# Altium schematic sheet BSE_SCH.SchDoc(BSE_SCH)
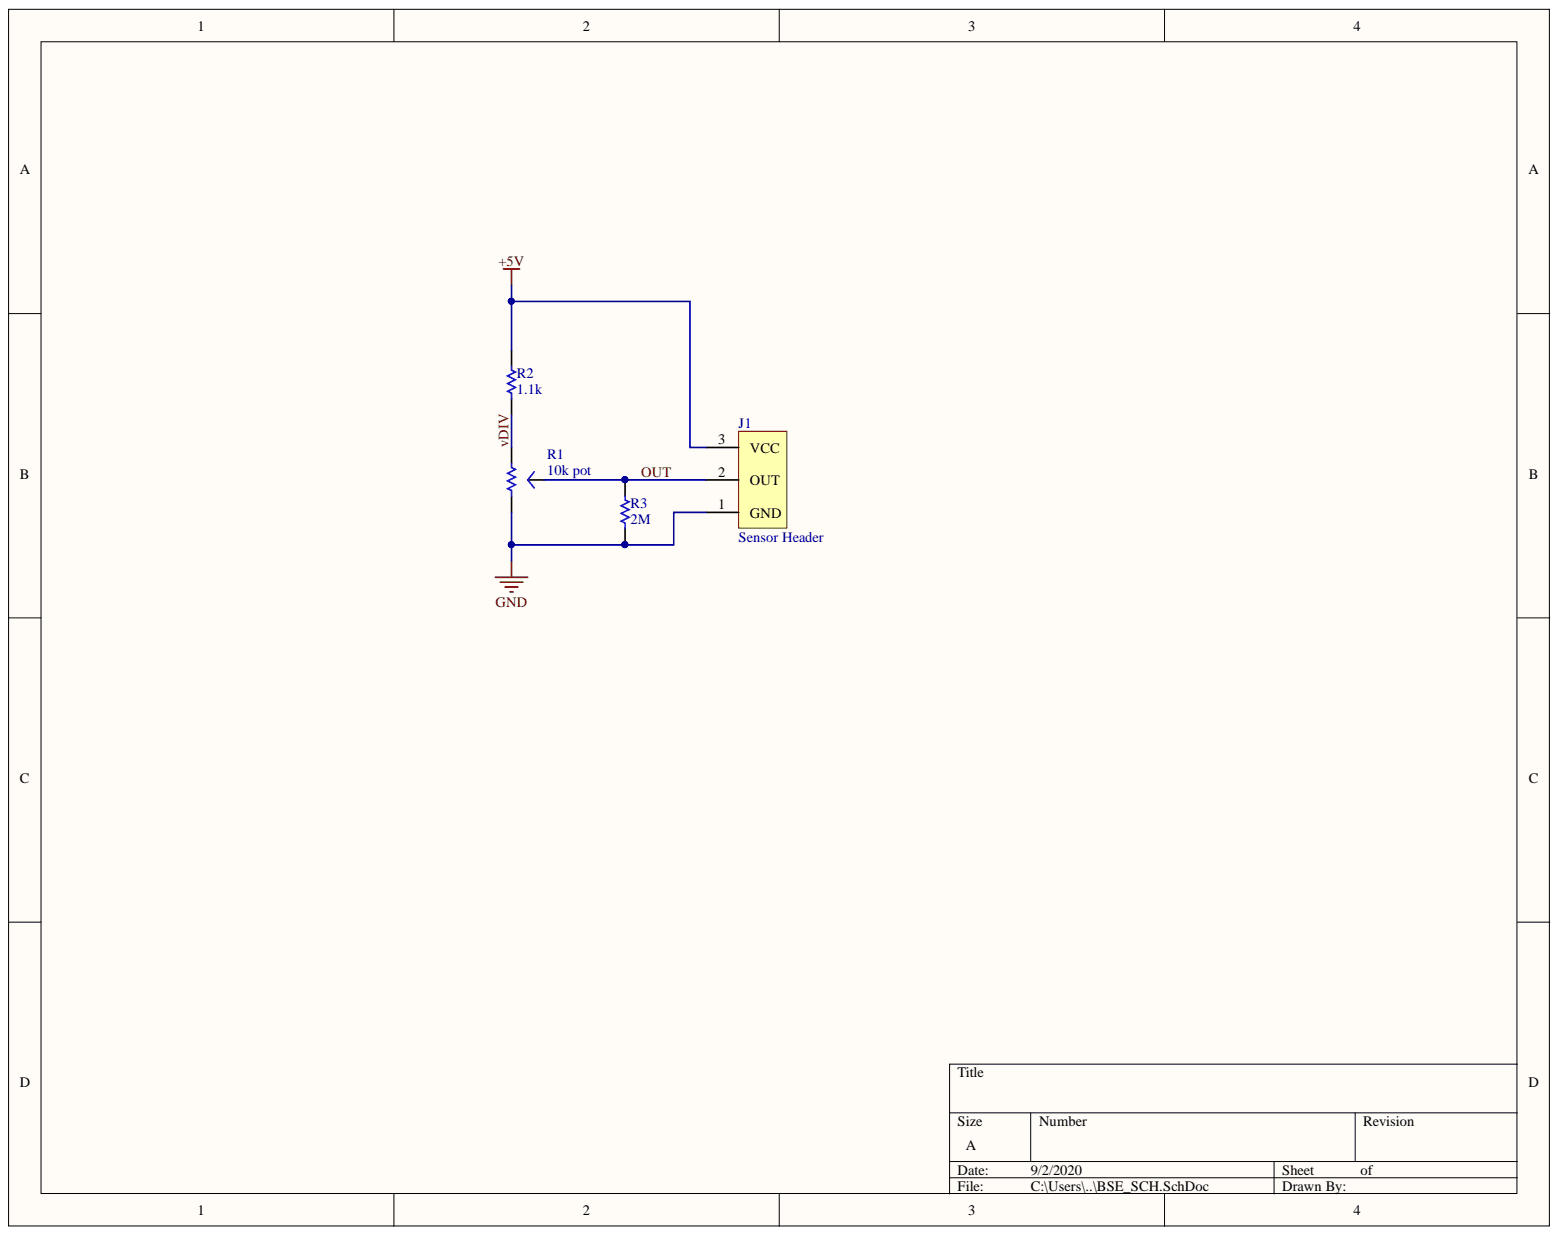
[diagram p0: BSE_SCH.SchDoc]

## Parts
J1  Sensor Header  [Header3POS-SMD]
R1  10k pot  [10k Rotary Encoder]
R2  1.1k  [RES 0603]
R3  2M  [RES 0603]

## Nets
+5V: J1.3 R2.2
GND: J1.1 R1.1 R3.1
OUT: J1.2 R1.2 R3.2
vDIV: R1.3 R2.1

## Library data of the parts
J1 = Header3POS-SMD: Conn Shrouded Header (4 Sides) HDR 3 POS 1.5mm Solder RA SMD Pico-SPOX™ T/R; Manufacturer Part Number: 0874380343; Manufacturer: Molex; Number of Positions: 3; Max Voltage Rating (AC): 350V; Contact Resistance: 40mR; Connector Type: Male Pin; Termination: Solder; Supplier Part Number 1: WM7647CT-ND; Supplier 1: Digi-Key; Stock 1: 3095; Radiation Hardening: No; Pricing 1: 1=0.6, 10=0.561, 100=0.4304, 500=0.37422 (USD); Pitch: 1.5mm; Packaging: Tape and Reel; Orientation: Right Angle; Number of Rows: 1; Number of Contacts: 3; Mount: Surface Mount; Min Operating Temperature: -55°C; Max Operating Temperature: 105°C; Length: 5.8mm; Housing Material: Thermoplastic; Housing Color: Natural; Depth: 5.75mm; Contact Plating: Tin; Contact Mating Length: 0.106inch; Contact Material: Brass; ComponentLink2URL: http://rhu004.sma-promail.com/SQLImages/kelmscott/Molex/PDF_Images/USA-235.pdf?src-supplier=Digi-Key; ComponentLink2Description: http://rhu004.sma-promail.com/SQLImages/kelmscott/Molex/PDF_Images/USA-235.pdf?src-supplier=Digi-Key; ComponentLink1URL: http://www.molex.com/webdocs/datasheets/pdf/en-us/0874380343_PCB_HEADERS.pdf?src-supplier=Avnet; ComponentLink1Description: http://www.molex.com/webdocs/datasheets/pdf/en-us/0874380343_PCB_HEADERS.pdf?src-supplier=Avnet; Component Kind: Standard; Color: Beige; Library Name: BSE_SCH_lib.SchLib
R1 = 10k rotary encoder: Res Cermet Trimmer 10K Ohm 30% 1(Elec)/1(Mech)Turn 4mm (11 X 14.9 X 3.1mm) Gull Wing SMD T/R; Manufacturer Part Number: SV01A103AEA01R00; Manufacturer: Murata; Temperature Coefficient: 500ppm/°C; Sensing Method: Resistive; Resistance: 10kR; Number of Turns: 1; Linearity: 2%; Actuator Type: Hole for Shaft; Type: Potentiometer; Tolerance: 30%; Termination: Gull Wing; Supplier Part Number 1: 490-7023-1-ND; Supplier 1: Digi-Key; Stock 1: 998; Pricing 1: 1=2.46, 5=2.328, 10=1.995, 25=1.596, 50=1.4628, 100=1.3299, 500=1.16366 (USD); Packaging: Tape and Reel; Package Quantity: 1000; Mount: Surface Mount; Min Operating Temperature: -40°C; Military Standard: Not; Max Operating Temperature: 85°C; Length: 11mm; Lead Free: Lead Free; Height: 2.1mm; Depth: 14.9mm; ComponentLink4URL: http://uk.rs-online.com/webdocs/13ec/0900766b813ecb07.pdf?src-supplier=RSComponents; ComponentLink4Description: http://uk.rs-online.com/webdocs/13ec/0900766b813ecb07.pdf?src-supplier=RSComponents; ComponentLink3URL: http://www.murata.com/~/media/webrenewal/support/library/catalog/products/sensor/rotaryposition/r51e.ashx?src-supplier=Digi-Key; ComponentLink3Description: http://www.murata.com/~/media/webrenewal/support/library/catalog/products/sensor/rotaryposition/r51e.ashx?src-supplier=Digi-Key; ComponentLink2URL: https://www.murata.com/en-us/products/productdata/8796781117470/S0070E.pdf?src-supplier=Digi-Key; ComponentLink2Description: https://www.murata.com/en-us/products/productdata/8796781117470/S0070E.pdf?src-supplier=Digi-Key; ComponentLink1URL: http://www1.futureelectronics.com/doc/MURATA/SV01A103AEA01R00.pdf?src-supplier=Future+Electronics; ComponentLink1Description: http://www1.futureelectronics.com/doc/MURATA/SV01A103AEA01R00.pdf?src-supplier=Future+Electronics; Component Kind: Standard; Case/Package: SMD/SMT; Library Name: BSE_SCH_lib.SchLib
R2 = RES 1.1k: ERJPA3 Resistors 0.25W 0603 1K1 1%; Manufacturer Part Number: ERJ-PA3F1101V; Manufacturer: Panasonic; Voltage Rating (DC): 150V; Temperature Coefficient: 100ppm/°C; Resistance: 1.1kR; Power Rating: 250mW; Width: 0.031inch; Voltage Rating: 150V; Tolerance: 1%; Termination: Solder; Supplier Part Number 1: P1.1KBYCT-ND; Supplier 1: Digi-Key; Stock 1: 10551; REACH SVHC: No SVHC; Pricing 1: 1=0.13, 10=0.12, 100=0.0461, 1000=0.02028, 2500=0.01752 (USD); Packaging: Cut Tape; Number of Terminations: 2; Mount: Surface Mount; Min Operating Temperature: -55°C; Max Power Dissipation: 250mW; Max Operating Temperature: 155°C; Length: 0.063inch; Height: 0.018inch; Features: Automotive AEC-Q200; Depth: 0.8mm; Composition: Thick Film; ComponentLink2URL: http://industrial.panasonic.com/cdbs/www-data/pdf/RDO0000/AOA0000C331.pdf?src-supplier=Farnell; ComponentLink2Description: http://industrial.panasonic.com/cdbs/www-data/pdf/RDO0000/AOA0000C331.pdf?src-supplier=Farnell; ComponentLink1URL: http://www.farnell.com/datasheets/1801181.pdf?src-supplier=Element14; ComponentLink1Description: http://www.farnell.com/datasheets/1801181.pdf?src-supplier=Element14; Component Kind: Standard; Case Code (Metric): 1608; Case Code (Imperial): 0603; Case/Package: 0603; Library Name: BSE_SCH_lib.SchLib
R3 = RES 2M: Res Thick Film 0603 2MegaOhm 1% 1/4W ±100ppm/K Molded Paper T/R; Manufacturer Part Number: RCS06032M00FKEA; Manufacturer: Vishay; Supplier Part Number 1: 541-RCS06032M00FKEACT-ND; Supplier 1: Digi-Key; Stock 1: 5000; Pricing 1: 1=0.14, 10=0.123, 100=0.0472, 1000=0.02075, 2500=0.01792 (USD); Component Kind: Standard; Library Name: BSE_SCH_lib.SchLib
